# Altium schematic sheet inverter_module.SchDoc
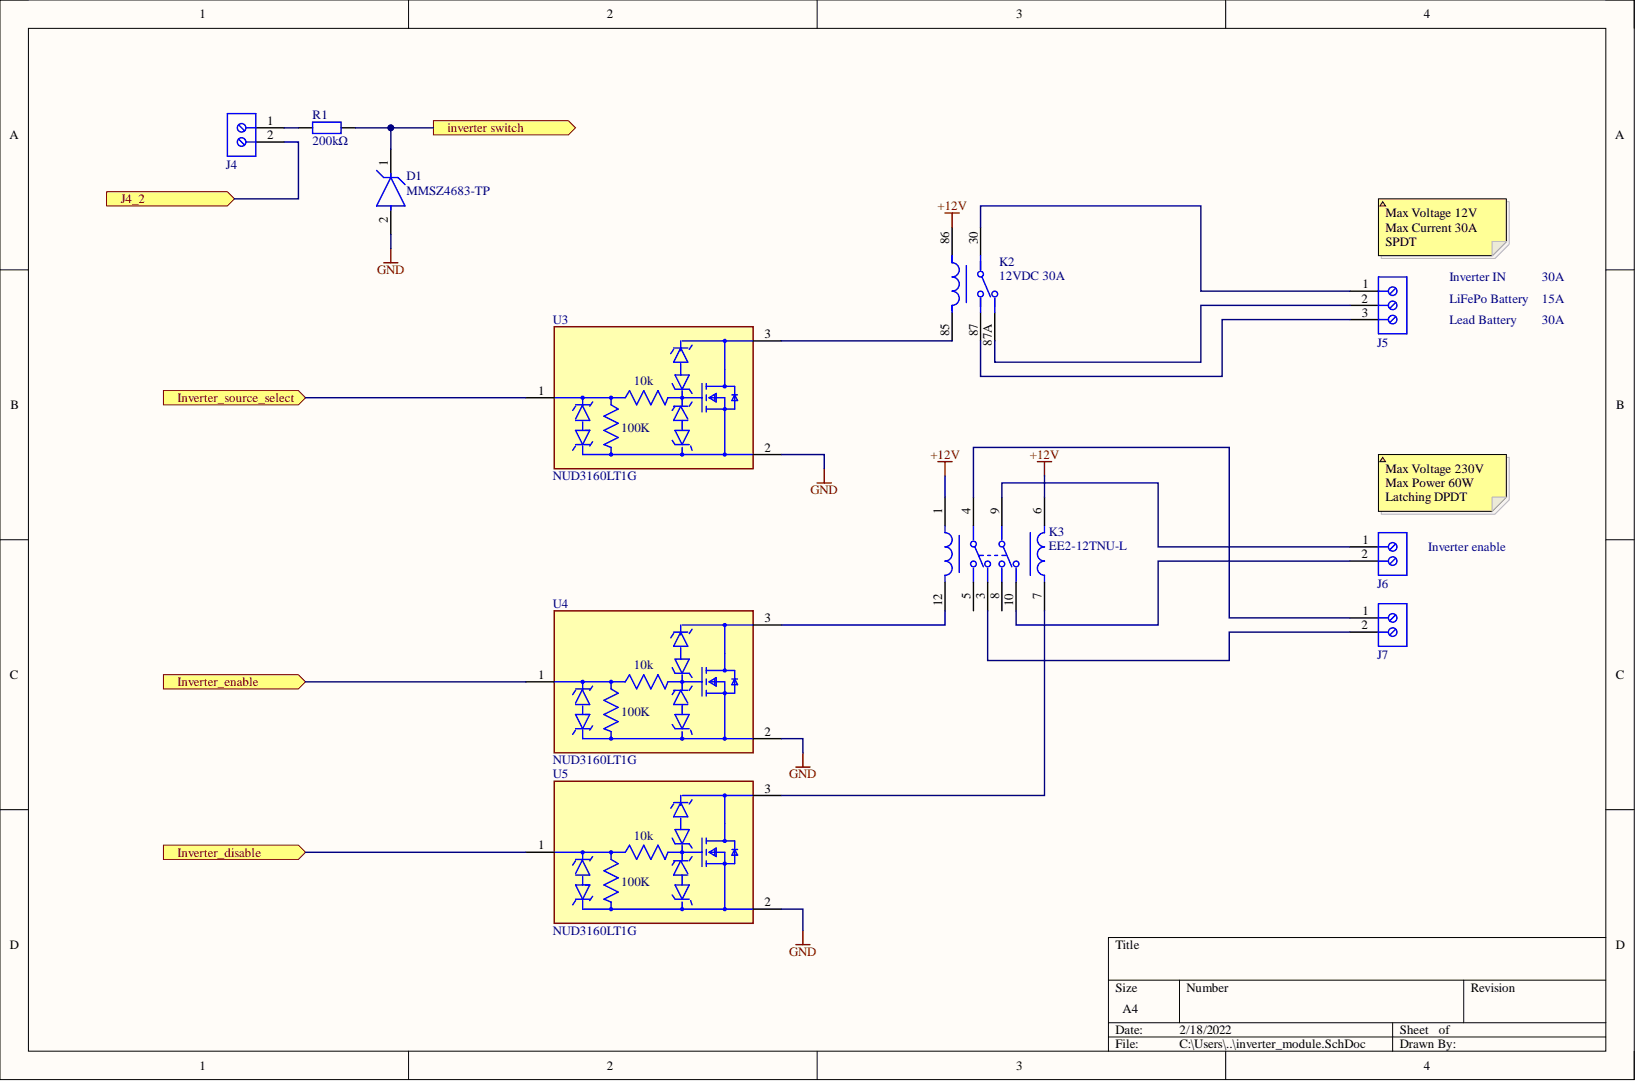
[diagram p0: inverter_module.SchDoc]

## Parts
D1  MMSZ4683-TP  [FP-SOD-123-MFG]
J4  282836-2  [TECO-282836-2_V]  (value not drawn)
J5  282836-3  [TE_282836-3]  (value not drawn)
J6  282836-2  [TECO-282836-2_V]  (value not drawn)
J7  282836-2  [TECO-282836-2_V]  (value not drawn)
K2  12VDC 30A  [automotive_relay]
K3  EE2-12TNU-L  [FP-EE2-12TNU-L-MFG]
R1  200kΩ  [RESC2013X50X30ML20T20]
U3  NUD3160LT1G  [FP-318-08-MFG]
U4  NUD3160LT1G  [FP-318-08-MFG]
U5  NUD3160LT1G  [FP-318-08-MFG]

## Ports
inverter switch, Inverter_disable, Inverter_enable, Inverter_source_select, J4_2

## Nets
+12V: K2.86 K3.1 K3.6
GND: D1.2 U3.2 U4.2 U5.2
inverter switch: D1.1 R1.1 ; port inverter switch
Inverter_disable: U5.1 ; port Inverter_disable
Inverter_enable: U4.1 ; port Inverter_enable
Inverter_source_select: U3.1 ; port Inverter_source_select
J4_2: J4.2 ; port J4_2
NetJ4_1: J4.1 R1.2
NetJ5_1: J5.1 K2.30
NetJ5_2: J5.2 K2.87A
NetJ5_3: J5.3 K2.87
NetJ6_1: J6.1 K3.9
NetJ6_2: J6.2 K3.10
NetJ7_1: J7.1 K3.4
NetJ7_2: J7.2 K3.3
NetK2_85: K2.85 U3.3
NetK3_7: K3.7 U5.3
NetK3_12: K3.12 U4.3

Not connected: K3 5 8

## Library data of the parts
D1 = CMP-56208-000004-1: DIODE ZENER 3V 500MW SOD123; Element Configuration: Single; Forward Voltage: 950mV; Max Reverse Leakage Current: 800nA; Tolerance: 5%; Component Kind: Standard; Lead Free: Lead Free; Library Name: Altium Content Vault; Max Operating Temperature: 150°C; Max Power Dissipation: 500mW; Min Operating Temperature: -55°C; Mount: Surface Mount; Number of Pins: 2; Package Quantity: 1; Packaging: Tape and Reel; Peak Reverse Current: 800nA; Power Dissipation: 500mW; Radiation Hardening: No; Voltage Tolerance: 5%; Working Voltage: 3V; Zener Voltage: 3V
J4 J6 J7 = CMP-1684-00017-1: Female Header, Pitch 5 mm, 1 x 2 Position, Height 10 mm, Tail Length 3.5 mm, Rohs, Bulk; Manufacturer: TE Connectivity; 3D Model: 282836-2.pdf; Clamp Material - Plating: Brass-NickelPlated; Current: 13.5A; Dynamic Catalog: BuchananSeries; Featured Product: EurostyleTerminalBlockConnectors; Mating Orientation: HorizontalwithBoard; Number of Levels: 1; Other Names: 5ULV-02B  A98076; Pitch: 0.197"(5.00mm); Positions Per Level: 2; Product Training Modules: Wire-to-BoardEurostyleTerminalBlocks; Screw Thread: M3; Torque - Screw: 0.68Nm(6Lb-In); Voltage: 300V; Wire Gauge: 16-30AWG; Wire Termination: Screw-RisingCageClamp; Category: Connectors,Interconnects; Color: Green; Component Kind: Standard; Contact Material - Plating: Brass-TinPlated; Datasheet URL: http://www.te.com.cn/commerce/DocumentDelivery/DDEController?Action=showdoc&DocId=Catalog+Section%7F1308389_EUROSTYLE_TERMINAL_BLOCKS%7F0607%7Fpdf%7FEnglish%7FENG_CS_1308389_EUROSTYLE_TERMINAL_BLOCKS_0607.pdf%7F282836-2; Family: TerminalBlocks-WiretoBoard; Features: Interlocking(Side); Housing Material: Polyamide(PA66),Nylon6/6; Library Name: Altium Content Vault; Manufacturer URL: http://www.te.com; Mounting Type: ThroughHole; Package Description: Female Header, Pitch 5 mm, 1 x 2 Position, Height 10 mm, Tail Length 3.5 mm; Package Reference: THD; Packaging: Bulk; RoHS Information: 282836-2StatementofCompliance; Screw Material - Plating: Brass-NickelPlated; Series: Buchanan; Standard Package: 300
J5 = 282836-3: Connector, Terminal Block; Wire Receptacle; 3 Pos.; 16-30 AWG; 0.197 in. CL; Sid; Manufacturer: TE Connectivity; Approvals: UL; Closed End: No (Without); Current: 13.5A; Interlock: Yes (With); Max Supply Voltage (AC): 300V; Number of Circuits: 3; Number of Levels: 1; Pitch: 5mm; Plating: Brass; Tail Length: 3.5mm; Thread Size: M3; Voltage Rating (AC): 250V; Wire Gauge (Max): 30AWG; Wire Gauge (Min): 16AWG; Category: Terminal Blocks; Color: Green; Component Kind: Standard; ComponentLink1Description: https://datasheet.ciiva.com/15169/getdatasheetpartid-155856-15169554.pdf?src-supplier=Verical; ComponentLink1URL: https://datasheet.ciiva.com/15169/getdatasheetpartid-155856-15169554.pdf?src-supplier=Verical; ComponentLink2Description: https://datasheet.ciiva.com/16305/eng-cs-1308389-eurostyle-terminal-blocks-0607-16305242.pdf?src-supplier=Future+Electronics; ComponentLink2URL: https://datasheet.ciiva.com/16305/eng-cs-1308389-eurostyle-terminal-blocks-0607-16305242.pdf?src-supplier=Future+Electronics; ComponentLink3Description: https://datasheet.ciiva.com/19460/750661-19460522.pdf?src-supplier=Jameco; ComponentLink3URL: https://datasheet.ciiva.com/19460/750661-19460522.pdf?src-supplier=Jameco; ComponentLink4Description: https://datasheet.ciiva.com/11757/0900766b8002dc8a-11757406.pdf?src-supplier=RSComponents; ComponentLink4URL: https://datasheet.ciiva.com/11757/0900766b8002dc8a-11757406.pdf?src-supplier=RSComponents; ComponentLink5Description: https://datasheet.ciiva.com/823/eng-cd-282836-h10-823445.pdf?src-supplier=Digi-Key; ComponentLink5URL: https://datasheet.ciiva.com/823/eng-cd-282836-h10-823445.pdf?src-supplier=Digi-Key; ComponentLink6Description: https://datasheet.ciiva.com/30106/eng-cs-1308389-eurostyle-terminal-blocks-0607-30106198.pdf?src-supplier=Onlinecomponents.com; ComponentLink6URL: https://datasheet.ciiva.com/30106/eng-cs-1308389-eurostyle-terminal-blocks-0607-30106198.pdf?src-supplier=Onlinecomponents.com; ComponentLink7Description: https://datasheet.ciiva.com/14606/70086276-14606907.pdf?src-supplier=Allied+Electronics; ComponentLink7URL: https://datasheet.ciiva.com/14606/70086276-14606907.pdf?src-supplier=Allied+Electronics; ComponentLink8Description: https://datasheet.ciiva.com/16649/eng-cs-1308389-eurostyle-terminal-blocks-0607-16649389.pdf?src-supplier=Avnet+Europe; ComponentLink8URL: https://datasheet.ciiva.com/16649/eng-cs-1308389-eurostyle-terminal-blocks-0607-16649389.pdf?src-supplier=Avnet+Europe; ComponentLink9Description: https://datasheet.ciiva.com/3141/eng-cs-1308389-eurostyle-terminal-blocks-0607-3141119.pdf?src-supplier=Avnet; ComponentLink9URL: https://datasheet.ciiva.com/3141/eng-cs-1308389-eurostyle-terminal-blocks-0607-3141119.pdf?src-supplier=Avnet; Connector Type: Receptacle; Contact Material: Brass; Contact Plating: Tin; Current Rating: 13.5A; Depth: 8.2mm; ELV: Compliant; Flammability Rating: UL94 V-0; Housing Color: Green; Housing Material: Polyamide; Insulation Material: Nylon; Lead Free: Lead Free; Length: 15mm; Library Name: Schlib.SchLib; Manufacturer Part Number: 282836-3; Max Current Rating: 13.5A; Max Voltage Rating (AC): 300V; Mount: Through Hole,Board; Number of Contacts: 3; Number of Poles: 3; Number of Positions: 3; Number of Positions Per Level: 3; Number of Rows: 1; Orientation: Straight; Package Quantity: 200; Packaging: Bulk; Pricing 1: 1=0.96000, 10=0.57500, 50=0.57500, 100=0.48300, 600=0.45700, 1000=0.40600, 2600=0.36800, 4800=0.33000, 10000=0.33000, 11200=0.31700, 25600=0.31100 (USD); Radiation Hardening: No; REACH SVHC: Unknown; RMS Current (Irms): 13.5A; RoHS: Yes; RoHS Compliant: Yes; Screw Flange: No (Without); Screwless Terminal Block: No (Without); Series: Buchanan; Side Stackable: Yes (With); Stock 1: 394; Supplier 1: Mouser; Supplier Part Number 1: 571-2828363; Termination: Screw; Voltage: 300V; Voltage Rating: 300V; Width: 10mm; Wire/Cable Gauge: 16AWG; Working Voltage: 250V
K2 = Relay automotive: Power Relay 12VDC 90(NO)/30(NC)A SPDT(26.5x26.5x24.5)mm Plug-In; Manufacturer: TE Connectivity; Manufacturer Part Number: 1432787-1; Coil Current: 151mA; Coil Power: 1.8W; Coil Resistance: 79.5R; Coil Type: Non-Latching; Coil Voltage (DC): 12V; Current Rating: 40A; Operate Time: 10ms; Release Time: 13ms; Throw Configuration: SPDT,DPDT; Voltage Rating (DC): 14V; Category: Power Relays; Component Kind: Standard; ComponentLink1Description: https://datasheet.ciiva.com/30468/0900766b8122a645-30468979.pdf?src-supplier=RSComponents; ComponentLink1URL: https://datasheet.ciiva.com/30468/0900766b8122a645-30468979.pdf?src-supplier=RSComponents; ComponentLink2Description: https://datasheet.ciiva.com/30061/eng-cd-1432787-1-b-30061776.pdf?src-supplier=Onlinecomponents.com; ComponentLink2URL: https://datasheet.ciiva.com/30061/eng-cd-1432787-1-b-30061776.pdf?src-supplier=Onlinecomponents.com; Contact Current Rating: 30A; Contact Material: Tin,Silver; Lead Free: Lead Free; Library Name: Schlib.SchLib; Max Operating Temperature: 85°C; Min Operating Temperature: -40°C; Mount: Socketable,Plug-In,Socket; Number of Pins: 5; Package Quantity: 100; Packaging: Bulk; Pricing 1: 1=5.77600, 10=5.49900, 25=5.22200, 50=4.76500, 150=4.16900 (USD); Relay Type: Automotive; RoHS: Yes; Stock 1: 124; Supplier 1: RSComponents; Supplier Part Number 1: 7826947; Termination: Plug
K3 = CMP-03195-000019-1: RELAY GEN PURPOSE DPDT 2A 12VDC; Coil Current: 11.7mA; Coil Power: 140mW; Coil Resistance: 1.028kR; Coil Type: Latching, Dual Coil; Coil Voltage (DC): 12V; Max Voltage Rating (AC): 250V; Max Voltage Rating (DC): 220V; Number of Poles: 1; Operate Time: 2ms; Relay Type: General Purpose; Release Time: 1ms; Component Kind: Standard; Contact Current Rating: 2A; Contact Material: Gold; Current Rating: 2A; Depth: 7.5mm; Height: 9mm; Length: 15mm; Library Name: Altium Content Vault; Max Current Rating: 2A; Max Operating Temperature: 85°C; Min Operating Temperature: -40°C; Mount: Surface Mount; Number of Pins: 10; Package Quantity: 500; Packaging: Tape and Reel; Power Consumption: 140mW; Radiation Hardening: No; REACH SVHC: No SVHC; RoHS Compliant: Yes; Termination: Gull Wing; Throw Configuration: DPDT
R1 = Res_0805: Resistor; Component Kind: Standard; Library Name: PCB_Project.SchLib; Signal Integrity: Res; Simulation: RESISTOR
U3-U5 = CMP-07123-000002-1: IC INDCT LOAD DRVR INDUST SOT23; Manufacturer: ON Semiconductor; Fall Time: 622ns; Max Junction Temp: +150°C; Max Power Dissipation: 225mW; Max Supply Voltage: 60V; Number Of Drivers: 1; Output Current: 150mA; Output Voltage: 48V; Propagation Delay: 1160ns; Rise Time: 600ns; Standoff Height: 0.01mm; Ambient Temperature Range High: +125°C; Ambient Temperature Range Low: -40°C; Automotive: No; Category: IC; Component Kind: Standard; ComponentLink2Description: Datasheet; ComponentLink2URL: https://www.onsemi.com/pub/Collateral/NUD3160-D.PDF; Device Class L1: Integrated Circuits (ICs); Device Class L2: Power Management ICs; Device Class L3: Gate Drivers; Digikey Description: IC INDCT LOAD DRVR INDUST SOT23; Footprint Url: https://www.onsemi.com/pub/Collateral/318-08.PDF; Height: 1.11mm; Lead Free: Yes; Library Name: Altium Content Vault; Manufacturer Part Number: NUD3160LT1G; Mouser Description: MOSFET 61V Industrial Load Driver; Number Of Outputs: 1; Octopart: https://octopart.com/nud3160lt1g-on+semiconductor-470723; Package: 318-08; RoHS: TRUE; Temperature: -40°C to +150°C; Temperature Range High: +150°C; Temperature Range Low: -40°C
